# Altium schematic sheet Motor.SchDoc
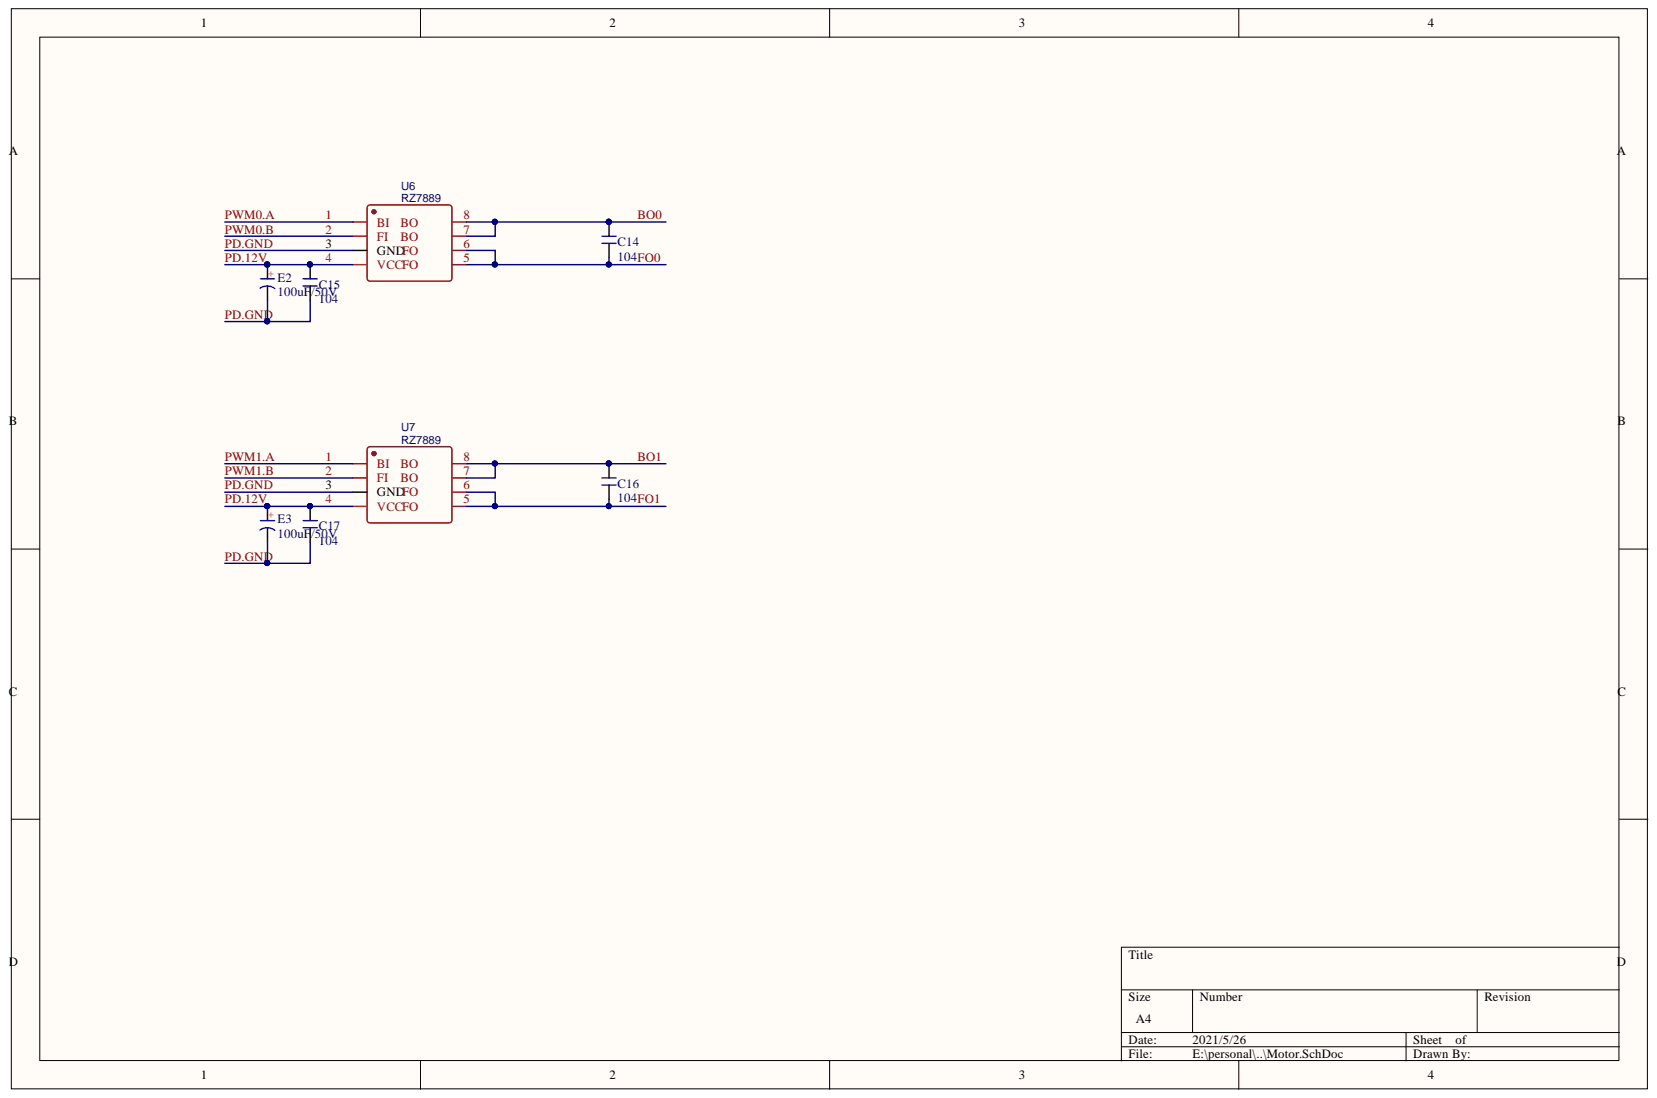
[diagram p0: Motor.SchDoc]

## Parts
C14  104  [C 0603]
C15  104  [C 0603]
C16  104  [C 0603]
C17  104  [C 0603]
E2  100uF/50V  [CM E(8*10.2)]
E3  100uF/50V  [CM E(8*10.2)]
U6  RZ7889  [SOP8_L]
U7  RZ7889  [SOP8_L]

## Nets
BO0: C14.2 U6.7 U6.8
BO1: C16.2 U7.7 U7.8
FO0: C14.1 U6.5 U6.6
FO1: C16.1 U7.5 U7.6
PD.12V: C15.2 C17.2 E2.1 E3.1 U6.4(VCC) U7.4(VCC)
PD.GND: C15.1 C17.1 E2.2 E3.2 U6.3(GND) U7.3(GND)
PWM0.A: U6.1(BI)
PWM0.B: U6.2(FI)
PWM1.A: U7.1(BI)
PWM1.B: U7.2(FI)

## Library data of the parts
C14-C17 = C: 无极性贴片电容; 材质: X7R; 版权归属: 源创客; BOM名称: 无极性贴片电容; Component Kind: Standard; Library Name: 3.1   - 电阻-电容-电感.SchLib; 学习资源: www.ooocke.com
E2 E3 = C-CM: 贴片电解电容; 版权归属: 源创客; BOM名称: 贴片电解电容; Component Kind: Standard; Library Name: 电阻-电容-电感.SchLib; 学习资源: www.ooocke.com
U6 U7 = RZ7889: BOM_Manufacturer: RZ; BOM_Paste Type: recommend; BOM_Manufacturer Part: RZ7889; BOM_Supplier: LCSC; BOM_Supplier Part: C83668; Component Kind: Standard; spicePre: U; spiceSymbolName: RZ7889
